# Altium schematic sheet laser_power_bus.SchDoc
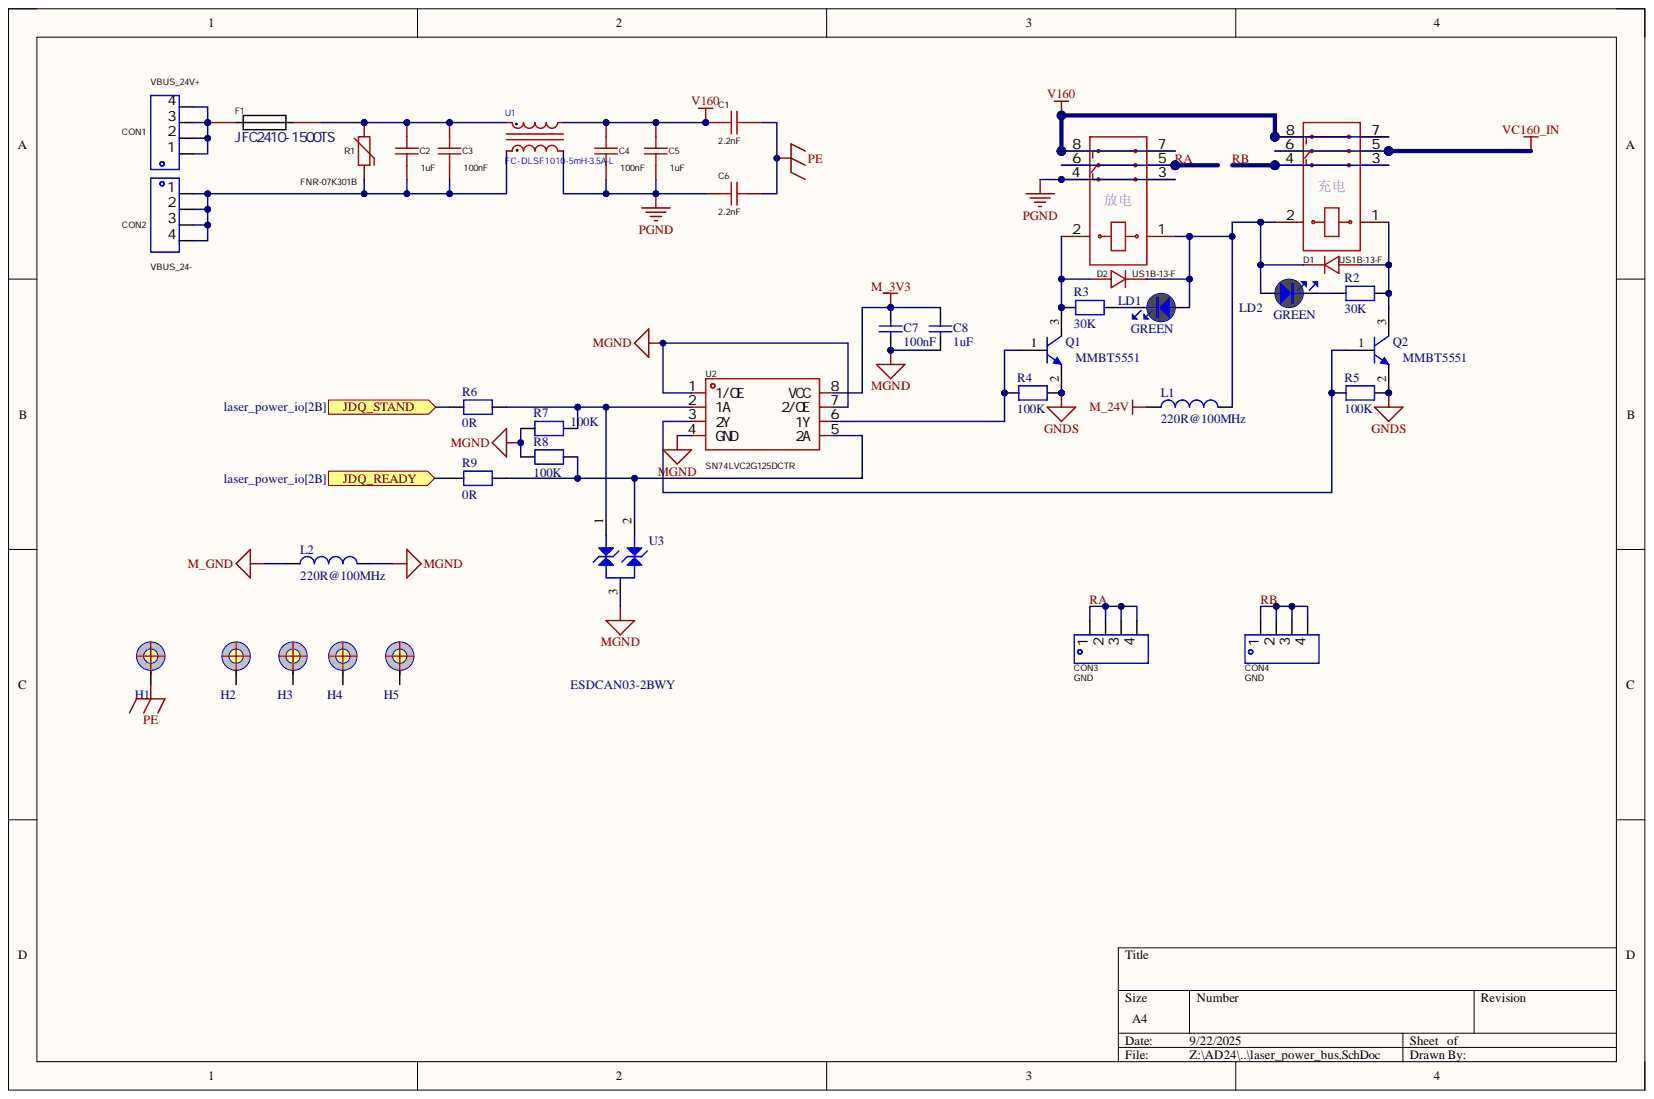
[diagram p0: laser_power_bus.SchDoc]

## Parts
C1  2.2nF  [CAP-TH_L6.5-W3.5-P7.50-D1.0]
C2  1uF  [CAP-TH_L13.0-W6.0-P10.00-D0.6]
C3  100nF  [CAP-TH_L13.0-W6.0-P10.00-D0.6]
C4  100nF  [CAP-TH_L13.0-W6.0-P10.00-D0.6]
C5  1uF  [CAP-TH_L13.0-W6.0-P10.00-D0.6]
C6  2.2nF  [CAP-TH_L6.5-W3.5-P7.50-D1.0]
C7  100nF  [0402]
C8  1uF  [0603L]
CON1  VBUS_24V+  [CONN-TH_T44001]
CON2  VBUS_24-  [CONN-TH_T44001]
CON3  GND  [CONN-TH_T44001]
CON4  GND  [CONN-TH_T44001]
D1  US1B-13-F  [SMA_L4.4-W2.8-LS5.4-RD]
D2  US1B-13-F  [SMA_L4.4-W2.8-LS5.4-RD]
F1  JFC2410-1500TS  [FUSE-SMD_L6.1-W2.6]
H1  POSITION HOLE C7D3R5  [PTH_350_700]  (value not drawn)  (no BOM)
H2  POSITION HOLE C7D3R5  [PTH_350_700]  (value not drawn)  (no BOM)
H3  POSITION HOLE C7D3R5  [PTH_350_700]  (value not drawn)  (no BOM)
H4  POSITION HOLE C7D3R5  [PTH_350_700]  (value not drawn)  (no BOM)
H5  POSITION HOLE C7D3R5  [PTH_350_700]  (value not drawn)  (no BOM)
K1  HF115F/024-1ZS3  [RELAY-TH_HF_115]  (value not drawn)
K2  HF115F/024-1ZS3  [RELAY-TH_HF_115]  (value not drawn)
L1  value 220R@100MHz  [L0603]  (hidden comment: MPZ1608S221ATD25)
L2  value 220R@100MHz  [L0603]  (hidden comment: MPZ1608S221ATD25)
LD1  value GREEN  [LED_0603_GREEN]  (hidden comment: LED_green)
LD2  value GREEN  [LED_0603_GREEN]  (hidden comment: LED_green)
Q1  MMBT5551  [NPN_SOT23]
Q2  MMBT5551  [NPN_SOT23]
R1  FNR-07K301B  [RES-TH_L16.5-W4.1-P7.50-D0.8-S4.10]
R2  30K  [R0603]
R3  30K  [R0603]
R4  100K  [R0603]
R5  100K  [R0603]
R6  0R  [R0603]
R7  100K  [R0603]
R8  100K  [R0603]
R9  0R  [R0603]
U1  FC- DLSF1010-5mH-3.5A-L  [XFMR-TH_FC-DLSF1010]
U2  SN74LVC2G125DCTR  [SM8_L3.2-W2.9-P0.65-LS4.3-BR]
U3  ESDCAN03-2BWY  [DIO_SOT323]

## Ports
JDQ_READY, JDQ_STAND

## Nets
GNDS: Q1.2 Q2.2 R4.2 R5.2
M_3V3: C7.1 C8.2 U2.8(VCC)
M_24V: L1.1
M_GND: L2.1
MGND: C7.2 C8.1 L2.2 R7.1 R8.1 U2.1(1/OE) U2.4(GND) U2.7(2/OE) U3.3
PE: C1.2 C6.1 H1.1
PGND: C4.1 C5.1 C6.2 K2.3 K2.4 U1.3
V160: C1.1 C4.2 C5.2 K1.7 K1.8 K2.7 K2.8 U1.2
VC160_IN: K1.5 K1.6
JDQ_READY: R9.1 ; port JDQ_READY
JDQ_STAND: R6.1 ; port JDQ_STAND
RA: CON3.1 CON3.2 CON3.3 CON3.4 K2.5 K2.6
RB: CON4.1 CON4.2 CON4.3 CON4.4 K1.3 K1.4
NetC2_1: C2.1 C3.1 CON2.1 CON2.2 CON2.3 CON2.4 R1.1 U1.4
NetC2_2: C2.2 C3.2 F1.2 R1.2 U1.1
NetCON1_1: CON1.1 CON1.2 CON1.3 CON1.4 F1.1
NetD1_1: D1.1 D2.1 K1.2 K2.1 L1.2 LD1.1 LD2.1
NetD1_2: D1.2 K1.1 Q2.3 R2.1
NetD2_2: D2.2 K2.2 Q1.3 R3.1
NetLD1_2: LD1.2 R3.2
NetLD2_2: LD2.2 R2.2
NetQ1_1: Q1.1 R4.1 U2.6(1Y)
NetQ2_1: Q2.1 R5.1 U2.3(2Y)
NetR6_2: R6.2 R7.2 U2.2(1A) U3.1
NetR8_2: R8.2 R9.2 U2.5(2A) U3.2

Not connected: H2 1; H3 1; H4 1; H5 1

## Library data of the parts
C1 C6 = KJKF222M2FF7035A075E: Manufacturer: KNSCHA(科尼盛); Add into BOM: yes; safety certification: UL；ENEC；VDE；CQC; Subclass: Y2; Tolerance: ±20%; Voltage(AC): 300V; Component Kind: Standard; Convert to PCB: yes; Datasheet: https://atta.szlcsc.com/upload/public/pdf/source/20210420/C2803195_BA0DBC8778A43A5602C5594119034AD5.pdf; Device: KJKF222M2FF7035A075E; JLCPCB Part Class: Extended Part; LCSC Part Name: 2.2nF ±20% 300V 等级:Y2; Library Name: HiPin_Library_AD.IntLib; Manufacturer Part: KJKF222M2FF7035A075E; PCB3D: CAP-TH_BD6.5-W3.5-P7.5; Supplier: LCSC; Supplier Footprint: 插件,P=7.5mm; Supplier Part: C2803195; Symbol: KJKF222M2FF7035A075E; Value: 2.2nF
C2 C5 = MPX104K31C3KN15600: Manufacturer: KNSCHA(科尼盛); Manufacturer Part: MPX105K3182X20046; safety certification: UL；CUL；KC；ENEC；VDE；CQC; Subclass: X2; Tolerance: ±10%; Voltage(AC): 275V；310V；305V; Component Kind: Standard; Library Name: HiPin_Library_AD.IntLib; Operating Temperature: -40℃~+110℃; PCB3D: CAP-TH_L13.0-W6.0-H12.0-P10.0; Supplier Footprint: 插件,P=10mm
C3 C4 = MPX104K31C3KN15600: Manufacturer: KNSCHA(科尼盛); Add into BOM: yes; safety certification: UL；CUL；KC；ENEC；VDE；CQC; Subclass: X2; Tolerance: ±10%; Voltage(AC): 275V；310V；305V; Component Kind: Standard; Convert to PCB: yes; Datasheet: https://atta.szlcsc.com/upload/public/pdf/source/20200225/C489079_75CB6A5338414DC85C4C6073302579B5.pdf; Device: MPX104K31C3KN15600; JLCPCB Part Class: Extended Part; LCSC Part Name: 100nF ±10% 275V 305V 310V 等级:X2; Library Name: HiPin_Library_AD.IntLib; Manufacturer Part: MPX104K31C3KN15600; Operating Temperature: -40℃~+110℃; PCB3D: CAP-TH_L13.0-W6.0-H12.0-P10.0; Supplier: LCSC; Supplier Footprint: 插件,P=10mm; Supplier Part: C489079; Symbol: MPX104K31C3KN15600; Value: 100nF
C7 = Capacitor: 50V, 5%, X7R; Manufacturer: muRata; Manufacturer_Part_Number: GRM155R71H104KE14D; Component Kind: Standard; ComponentLink1Description: Datasheet; ComponentLink1URL: http://tunmcdsvn.tun.st.com/Component_Datasheet_Library/Trunk/Capacitors/Yageo_Capacitor_NP0_16V-to-50V; Library Name: HiPin_Library_AD.IntLib
C8 = Capacitor_1: 16V, X5R, 10%; Internal_Part_Number: MCD-00009; Manufacturer: muRata; Manufacturer_Part_Number: GRM188R61E105KA12D; Component Kind: Standard; ComponentLink1Description: Yageo_Capacitor_X5R-series.pdf; ComponentLink1URL: http://tunmcdsvn.tun.st.com/Component_Datasheet_Library/Trunk/Capacitors/Yageo_Multilayer_capacitor_X5R_series_100pF_to_100uF; Library Name: HiPin_Library_AD.IntLib; Package: C0603; Value: 1uF
CON1-CON4 = T44001: Manufacturer: XFCN(兴飞); Add into BOM: yes; Component Kind: Standard; Convert to PCB: yes; Datasheet: https://atta.szlcsc.com/upload/public/pdf/source/20200107/C481448_4214B4EB74A46B70BD82BA8A456D4EDD.pdf; Device: T44001; JLCPCB Part Class: Extended Part; LCSC Part Name: 四脚 M4 二件套; Library Name: HiPin_Library_AD.IntLib; Manufacturer Part: T44001; Supplier: LCSC; Supplier Footprint: 插件; Supplier Part: C481447; Symbol: T44001
D1 D2 = US1B-13-F: Manufacturer: DIODES(美台); Add into BOM: yes; Average Rectified Current (Io): 1A; Forward Voltage (Vf@If): 1V@1A; Reverse Leakage Current: 5uA@100V; Reverse Recovery Time (trr): 50ns; Reverse Voltage (Vr): 100V; Component Kind: Standard; Convert to PCB: yes; Datasheet: https://atta.szlcsc.com/upload/public/pdf/source/20171129/C154439_15119539930141151354.pdf; Device: US1B-13-F; Diode Configuration: -; JLCPCB Part Class: Extended Part; LCSC Part Name: 电压:100V 电流:1A; Library Name: HiPin_Library_AD.IntLib; Manufacturer Part: US1B-13-F; Operating Temperature: -65℃~+150℃@(Tj); Supplier: LCSC; Supplier Footprint: SMA; Supplier Part: C154439; Symbol: US1B-13-F
F1 = 0451015.MRL: Manufacturer: Littelfuse(美国力特); Add into BOM: yes; Breaking Capacity: 50A; Current Rating: 15A; Type: 贴片式保险丝; Voltage Rating  (AC): 65V; Component Kind: Standard; Convert to PCB: yes; Datasheet: https://atta.szlcsc.com/upload/public/pdf/source/20151106/1457707549464.pdf; Device: 0451015.MRL; JLCPCB Part Class: Extended Part; LCSC Part Name: 65V贴片式保险丝; Library Name: HiPin_Library_AD.IntLib; Manufacturer Part: 0451015.MRL; Name: 0451015.MRL; Operating Temperature: -55℃~+125℃; PCB3D: FUSE-SMD_L6.1-W2.6-H2.6; Supplier: LCSC; Supplier Footprint: SMD; Supplier Part: C44480; Symbol: 0451015.MRL; Voltage Rating (DC): 65V
H1-H5 = MCD-00328: 螺丝焊盘; Part_Type: Mechanical; Initial_Board_Reference: MB1311; Item Type: Item; Path: ws/MCD_ApplicationBoards/Lists/MCDPARTSdatabase; ComponentLink1Description: Datasheet; Internal_Part_Number: MCD-00328; Library Name: HiPin_Library_AD.IntLib; Provided_By: n/a; Status: Released
K1 K2 = HF115F/024-1ZS3: Manufacturer: HF(宏发); Add into BOM: yes; Coil Rated Power: 400mW; Coil Resistance: 1.44kΩ; Coil Type: 无极性-单线圈; Coil Voltage: 24V; Contact Form: 一组转换:1C(单刀双掷-转换); Contact Rating: 16A@250VAC; Contact Resistance: 100mΩ; Operate Time: 15ms; Release Time: 8ms; Switching Current(Max): 16A; Switching Voltage(Max): 440V@AC；300V@DC; Symbol: HF-115F/024-1ZS3; Component Kind: Standard; Contact Material: AgCdO; Convert to PCB: yes; Datasheet: https://atta.szlcsc.com/upload/public/pdf/source/20180606/C194412_B4AD40CF326C73EFC55CF900E77D2578.pdf; Device: HF115F/024-1ZS3; JLCPCB Part Class: Extended Part; LCSC Part Name: 小型大功率直流24V继电器 单刀双掷; Library Name: HiPin_Library_AD.IntLib; Manufacturer Part: HF115F/024-1ZS3; Number of Pins: 8; Operating Temperature: -40℃~+85℃; PCB3D: RELAY-TH_HF_115; Supplier: LCSC; Supplier Footprint: DIP,12.7x29mm; Supplier Part: C194412
L1 L2 = MCD-00047: 阻抗@频率220Ω@100MHz,误差±25%,直流电阻50mΩ,额定电流2.2A; Manufacturer: TDK; Part_Type: Inductor; Database Table Name: MCD_PARTS$; Provided_By: SUBCO; Component Kind: Standard; ComponentLink1Description: Datasheet; ComponentLink1URL: http://tunmcdsvn.tun.st.com/Component_Datasheet_Library/Trunk/Inductors/TaiTech_Bead_FCM1608_series; ECCN_EU: n/a; ECCN_US: n/a; Internal_Part_Number: MCD-00047; Library Name: MCD_PARTS_database.DbLib; Manufacturer_Part_Number: MPZ1608S221ATD25; Status: Released
LD1 LD2 = LED_green: LED, Green, 0603; Internal_Part_Number: MCD-00050; Manufacturer: EVERLIGHT; Manufacturer_Part_Number: 19-213SYGC/S530-E2/TR8; Part_Type: Display; ECCN_EU: NEC; ECCN_US: EAR99; Provided_By: SUBCO; Component Kind: Standard; ComponentLink1Description: Datasheet; ComponentLink1URL: http://tunmcdsvn.tun.st.com/Component_Datasheet_Library/Trunk/Display/Everlight_SMD-LED-GREEN_19-213SYGC-S530-XX-TR8-v3.doc; Library Name: mb1367.IntLib; Package: LED 0603; Status: Released
Q1 Q2 = 9013-SOT23: NPN    集电极电流(Ic) 600mA    集射极击穿电压(Vceo) 160V    耗散功率(Pd) 300mW; Alternate_Manufacturer: ON SEMICONDUCTOR; Alternate_Manufacturer_Part_Number: MMBT2222LT1G / MMBT2222ALT1G / MMBT2222ALT3G; Internal_Part_Number: MCD-00073; Manufacturer_Part_Number: BSR14; ECCN_EU: NEC; ECCN_US: EAR99; Provided_By: SUBCO; Value: NPN SOT23; Component Kind: Standard; ComponentLink1Description: Datasheet; ComponentLink1URL: http://tunmcdsvn.tun.st.com/Component_Datasheet_Library/Trunk/IC/NXP_Transistor_BSR14; Library Name: HiPin_Library_AD.IntLib; Manufacturer: ON SEMICONDUCTOR; Package: SOT23; Part_Type: IC; Status: Released
R1 = 14D820K_C233153: 钳位电压: 500V  工作电压: 250V  工作电压(AC): 200V  峰值浪涌电流: 600A; Component Kind: Standard; Library Name: HiPin_Library_AD.IntLib; Manufacturer Part: FNR-07K301B; Supplier Footprint: 插件; Symbol: 14D820K_C233153
R2-R9 = RES: 1%; Value: 10K; Component Kind: Standard; Library Name: HiPin_Library_AD.IntLib
U1 = FC- DLSF1010-5mH-3.5A-L: Manufacturer: FC(方成); Add into BOM: yes; Current Rating: 3.5A; DC Resistance(DCR): 85mΩ; Inductance @ Frequency: 5mH; Number of Lines: 2; Voltage Rating (AC): 265V; Voltage Withstand: 1.5kV@AC,1mins; Component Kind: Standard; Convert to PCB: yes; Datasheet: https://atta.szlcsc.com/upload/public/pdf/source/20230918/E059DB83B2619EC93AA5E962655101CA.pdf; Device: FC- DLSF1010-5mH-3.5A-L; JLCPCB Part Class: Extended Part; LCSC Part Name: FC- DLSF1010-5mH-3.5A-L; Library Name: HiPin_Library_AD.IntLib; Manufacturer Part: FC- DLSF1010-5mH-3.5A-L; Operating Temperature: -40℃~+125℃; PCB3D: XFMR-TH_FC-DLSF1010; Supplier: LCSC; Supplier Footprint: DIP; Supplier Part: C7295674; Symbol: FC- DLSF1010-5MH-3.5A-L
U2 = SN74LVC2G125DCTR: Manufacturer: TI(德州仪器); Add into BOM: yes; Logic Family: 74LVC; Number of Bits per Element: 1; Number of Elements: 2; output type: 三态; Sink Current: 32mA; Supply Voltage: 1.65V~5.5V; Component Kind: Standard; Convert to PCB: yes; Datasheet: https://www.ti.com/cn/lit/gpn/sn74lvc2g125; Device: SN74LVC2G125DCTR; JLCPCB Part Class: Extended Part; LCSC Part Name: SN74LVC2G125DCTR; Library Name: HiPin_Library_AD.IntLib; Manufacturer Part: SN74LVC2G125DCTR; Operating Temperature: -40℃~+125℃; Source Current: 32mA; Supplier: LCSC; Supplier Footprint: MSOP-8; Supplier Part: C206035; Symbol: SN74LVC2G125DCTR
U3 = MCD-01228: Automotive dual-line Transil, transient voltage suppressor (TVS) for CAN bus. Compliant with interfaces in automotive: CAN-FD, LIN, FlexRay, MOST, SENT, USB; Manufacturer: STMICROELECTRONICS; Part_Type: Diode; Database Table Name: MCD_PARTS$; Initial_Board_Reference: MB1397; Item Type: Item; Path: ws/MCD_ApplicationBoards/Lists/MCDPARTSdatabase; Provided_By: SUBCO with ST control; Component Kind: Standard; ComponentLink1Description: Datasheet; ComponentLink1URL: http://tunmcdsvn.tun.st.com/Component_Datasheet_Library/Trunk/Diodes/STM_ESD_ESDCAN02-2BWY; Internal_Part_Number: MCD-01228; Library Name: MCD_PARTS_database.DbLib; Manufacturer_Part_Number: ESDCAN03-2BWY; Package: SOT323-3L; Status: Checked; Value: ESDCAN03-2BWY
